# Altium schematic sheet [SEN0253](V1.0).SchDot
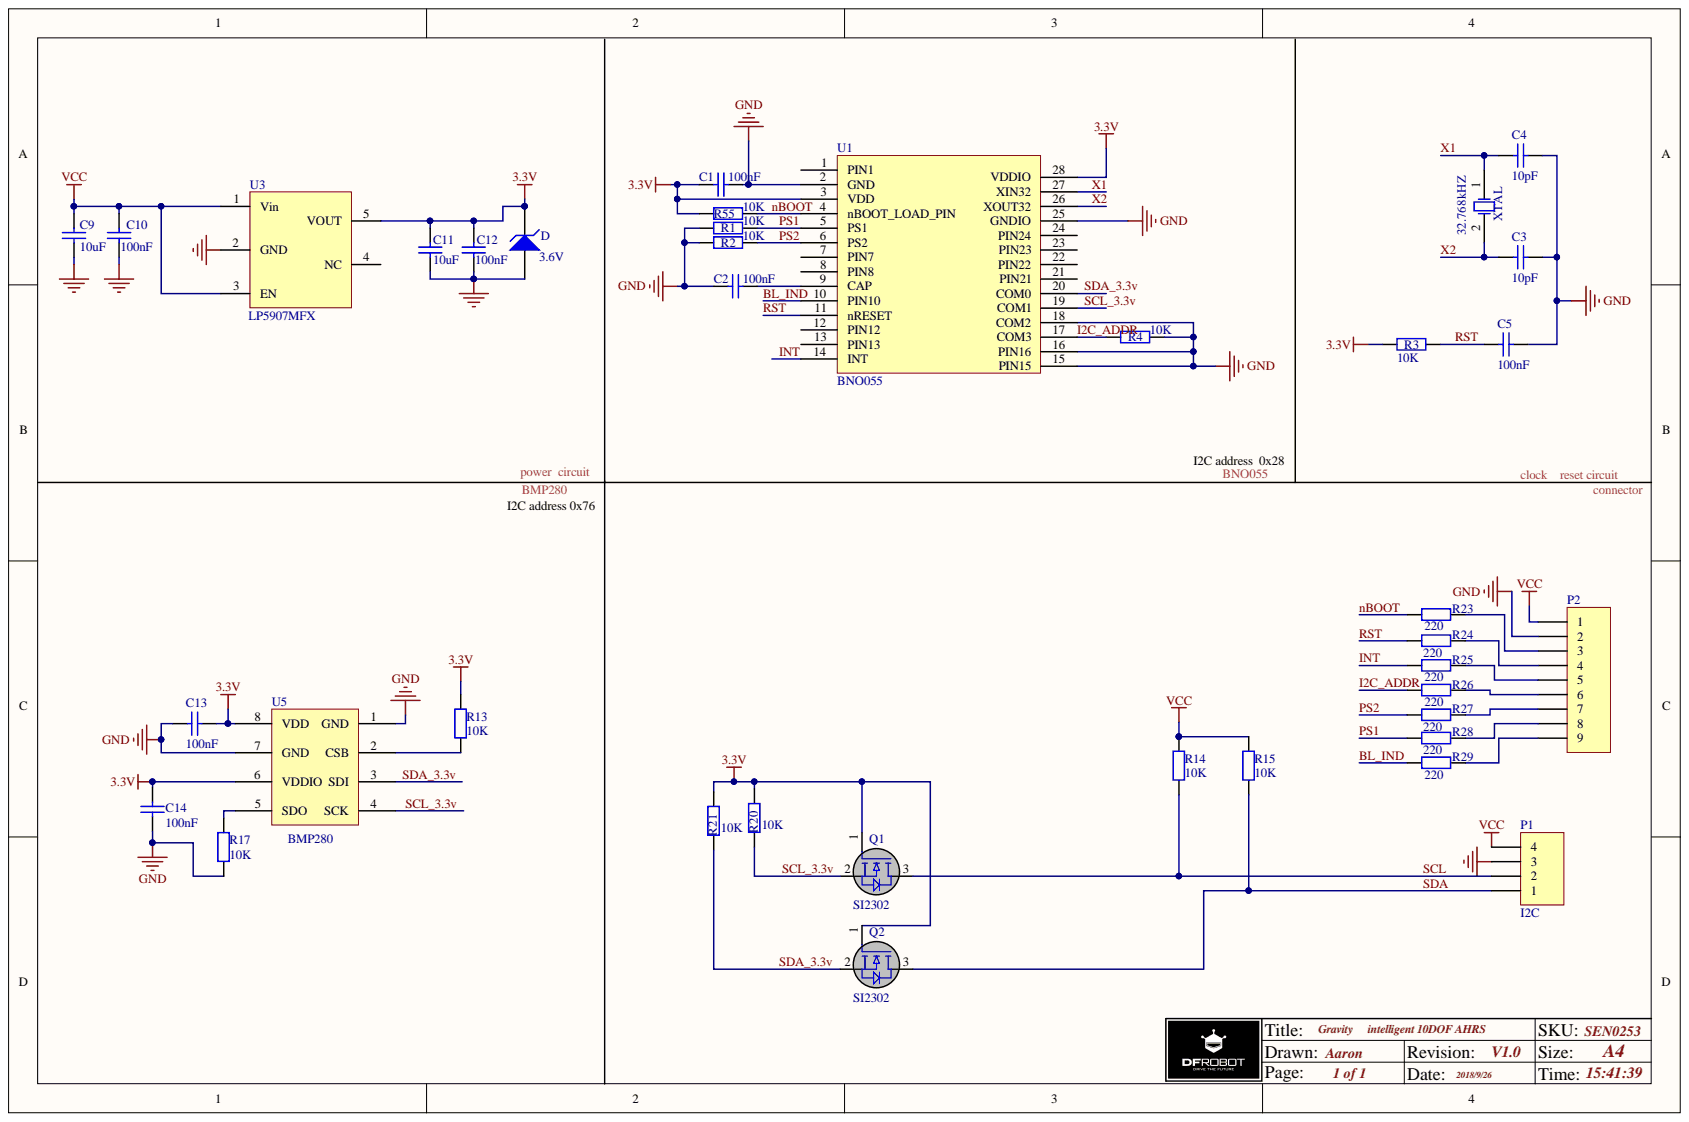
[diagram p0: [SEN0253](V1.0).SchDot]

## Parts
C1  value 100nF  [CAPC_0603_3D]  (hidden comment: 贴片电容0603-100nF-50V-X7R)
C2  value 100nF  [CAPC_0603_3D]  (hidden comment: 贴片电容0603-100nF-50V-X7R)
C3  value 10pF  [CAPC_0603_3D]  (hidden comment: 贴片电容0603-100nF-50V-X7R)
C4  value 10pF  [CAPC_0603_3D]  (hidden comment: 贴片电容0603-100nF-50V-X7R)
C5  value 100nF  [CAPC_0603_3D]  (hidden comment: 贴片电容0603-100nF-50V-X7R)
C9  value 10uF  [CAPC_0603_3D]  (hidden comment: 贴片电容0603-10uF-50V-X7R)
C10  value 100nF  [CAPC_0603_3D]  (hidden comment: 贴片电容0603-100nF-50V-X7R)
C11  value 10uF  [CAPC_0603_3D]  (hidden comment: 贴片电容0603-10uF-50V-X7R)
C12  value 100nF  [CAPC_0603_3D]  (hidden comment: 贴片电容0603-100nF-50V-X7R)
C13  value 100nF  [CAPC_0603_3D]  (hidden comment: 贴片电容0603-100nF-50V-X7R)
C14  value 100nF  [CAPC_0603_3D]  (hidden comment: 贴片电容0603-100nF-50V-X7R)
D  value 3.6V  [SOD-323]  (hidden comment: 稳压二极管-3.6v-SOD-323)
P1  PH2.0-4PWT卧式贴片座  [PH2.0-4PAWT]  (value not drawn)
P2  2.54黑色单排针-9P  [HDR1X9]  (value not drawn)  (no BOM)
Q1  value SI2302  [SOT23_3D]  (hidden comment: SI2302-N沟道MOS管-SOT-23)
Q2  value SI2302  [SOT23_3D]  (hidden comment: SI2302-N沟道MOS管-SOT-23)
R1  value 10K  [RES_0603_3D]  (hidden comment: 贴片电阻0603-10K-5%)
R2  value 10K  [RES_0603_3D]  (hidden comment: 贴片电阻0603-10K-5%)
R3  value 10K  [RES_0603_3D]  (hidden comment: 贴片电阻0603-10K-5%)
R4  value 10K  [RES_0603_3D]  (hidden comment: 贴片电阻0603-10K-5%)
R13  value 10K  [RES_0603_3D]  (hidden comment: 贴片电阻0603-10K-5%)
R14  value 10K  [RES_0603_3D]  (hidden comment: 贴片电阻0603-10K-5%)
R15  value 10K  [RES_0603_3D]  (hidden comment: 贴片电阻0603-10K-5%)
R17  value 10K  [RES_0603_3D]  (hidden comment: 贴片电阻0603-10K-5%)
R20  value 10K  [RES_0603_3D]  (hidden comment: 贴片电阻0603-10K-5%)
R21  value 10K  [RES_0603_3D]  (hidden comment: 贴片电阻0603-10K-5%)
R23  value 220  [RES_0603_3D]  (hidden comment: 贴片电阻0603-220R-5%)
R24  value 220  [RES_0603_3D]  (hidden comment: 贴片电阻0603-220R-5%)
R25  value 220  [RES_0603_3D]  (hidden comment: 贴片电阻0603-220R-5%)
R26  value 220  [RES_0603_3D]  (hidden comment: 贴片电阻0603-220R-5%)
R27  value 220  [RES_0603_3D]  (hidden comment: 贴片电阻0603-220R-5%)
R28  value 220  [RES_0603_3D]  (hidden comment: 贴片电阻0603-220R-5%)
R29  value 220  [RES_0603_3D]  (hidden comment: 贴片电阻0603-220R-5%)
R55  value 10K  [RES_0603_3D]  (hidden comment: 贴片电阻0603-10K-5%)
U1  BNO055  [BNO055]
U3  LDO稳压器LP5907MFX-3.3/NOPB-SOT23-5  [sot-23-5]  (value not drawn)
U5  value BMP280  [BMP280]  (hidden comment: 气压BMP280-LGA8)
XTAL  贴片晶振-2脚无源-32.768K-20ppm-3.2*1.5  [XTAL_S2_3.2*1.5]  (value not drawn)

## Nets
3.3V: C1.2 C11.2 C12.2 C13.2 C14.1 D.2 Q1.1 Q2.1 R3.1 R13.2 R20.2 R21.2 R55.1 U1.3(VDD) U1.28(VDDIO) U3.5(VOUT) U5.6(VDDIO) U5.8(VDD)
GND: C1.1 C2.2 C3.1 C4.1 C5.1 C9.1 C10.1 C11.1 C12.1 C13.1 C14.2 D.1 P1.3 P2.2 R1.1 R2.1 R4.2 R17.1 U1.2(GND) U1.15(PIN15) U1.16(PIN16) U1.18(COM2) U1.25(GNDIO) U3.2(GND) U5.1(GND) U5.7(GND)
VCC: C9.2 C10.2 P1.4 P2.1 R14.2 R15.2 U3.1(Vin) U3.3(EN)
BL_IND: R29.2 U1.10(PIN10)
I2C_ADDR: R4.1 R26.2 U1.17(COM3)
INT: R25.2 U1.14(INT)
NBOOT: R23.2 R55.2 U1.4(nBOOT_LOAD_PIN)
PS1: R1.2 R28.2 U1.5(PS1)
PS2: R2.2 R27.2 U1.6(PS2)
RST: C5.2 R3.2 R24.2 U1.11(nRESET)
SCL: P1.2 Q1.3 R14.1
SCL_3.3V: Q1.2 R20.1 U1.19(COM1) U5.4(SCK)
SDA: P1.1 Q2.3 R15.1
SDA_3.3V: Q2.2 R21.1 U1.20(COM0) U5.3(SDI)
X1: C4.2 U1.27(XIN32) XTAL.1
X2: C3.2 U1.26(XOUT32) XTAL.2
NetC2_1: C2.1 U1.9(CAP)
NetP2_3: P2.3 R23.1
NetP2_4: P2.4 R24.1
NetP2_5: P2.5 R25.1
NetP2_6: P2.6 R26.1
NetP2_7: P2.7 R27.1
NetP2_8: P2.8 R28.1
NetP2_9: P2.9 R29.1
NetR13_1: R13.1 U5.2(CSB)
NetR17_2: R17.2 U5.5(SDO)

Not connected: U1 1(PIN1) 7(PIN7) 8(PIN8) 12(PIN12) 13(PIN13) 21(PIN21) 22(PIN22) 23(PIN23) 24(PIN24); U3 4(NC)

## Library data of the parts
C1-C5 C13 C14 = Cap: Capacitor; Component Kind: Standard; Library Name: Miscellaneous Devices.IntLib; Signal Integrity: Cap; Simulation: CAP
  C1-C5: LatestRevisionDate: 17-Jul-2002; LatestRevisionNote: Re-released for DXP Platform.; PackageReference: RAD-0.3; Published: 8-Jun-2000; Publisher: Altium Limited
C9-C12 = Cap: Sort: 2; Component Kind: Standard; Signal Integrity: Cap; Simulation: CAP
D = D Zener: Zener Diode; Component Kind: Standard; LatestRevisionDate: 17-Jul-2002; LatestRevisionNote: Re-released for DXP Platform.; Library Name: Miscellaneous Devices.IntLib; PackageReference: DIODE-0.7; Published: 8-Jun-2000; Signal Integrity: D Zener; Simulation: ZENER; Publisher: Altium Limited
P1 = Header 4: Header, 4-Pin; : I2C; Component Kind: Standard; Library Name: Miscellaneous Connectors.IntLib; Signal Integrity: Connector
P2 = Header 9: Header, 9-Pin; LatestRevisionDate: 17-Jul-2002; LatestRevisionNote: Re-released for DXP Platform.; Library Name: Miscellaneous Connectors.IntLib; Signal Integrity: Connector; Publisher: Altium Limited
Q1 Q2 = MOSFET_N: Sort: 4; Component Kind: Standard
R1-R4 R13-R15 R17 R20 R21 R23-R29 R55 = Res2: Resistor; Component Kind: Standard; Library Name: Miscellaneous Devices.IntLib; Signal Integrity: Res; Simulation: RESISTOR
  R1-R4: LatestRevisionDate: 17-Jul-2002; LatestRevisionNote: Re-released for DXP Platform.; PackageReference: AXIAL-0.4; Published: 8-Jun-2000; Publisher: Altium Limited
U1 U5 = Component_1: Component Kind: Standard
  U1: Library Name: BNO055.SchLib
  U5: Library Name: BMP280.SchLib
U3 = LP5907: : LP5907MFX; Component Kind: Standard; Library Name: My_schlib.SchLib
XTAL = XTAL: Crystal Oscillator; : 32.768kHZ; Component Kind: Standard; LatestRevisionDate: 17-Jul-2002; LatestRevisionNote: Re-released for DXP Platform.; Library Name: Miscellaneous Devices.IntLib; PackageReference: R38; Published: 8-Jun-2000; Simulation: XTAL; Publisher: Altium Limited
